# Altium schematic sheet ET-GCLK.SchDoc
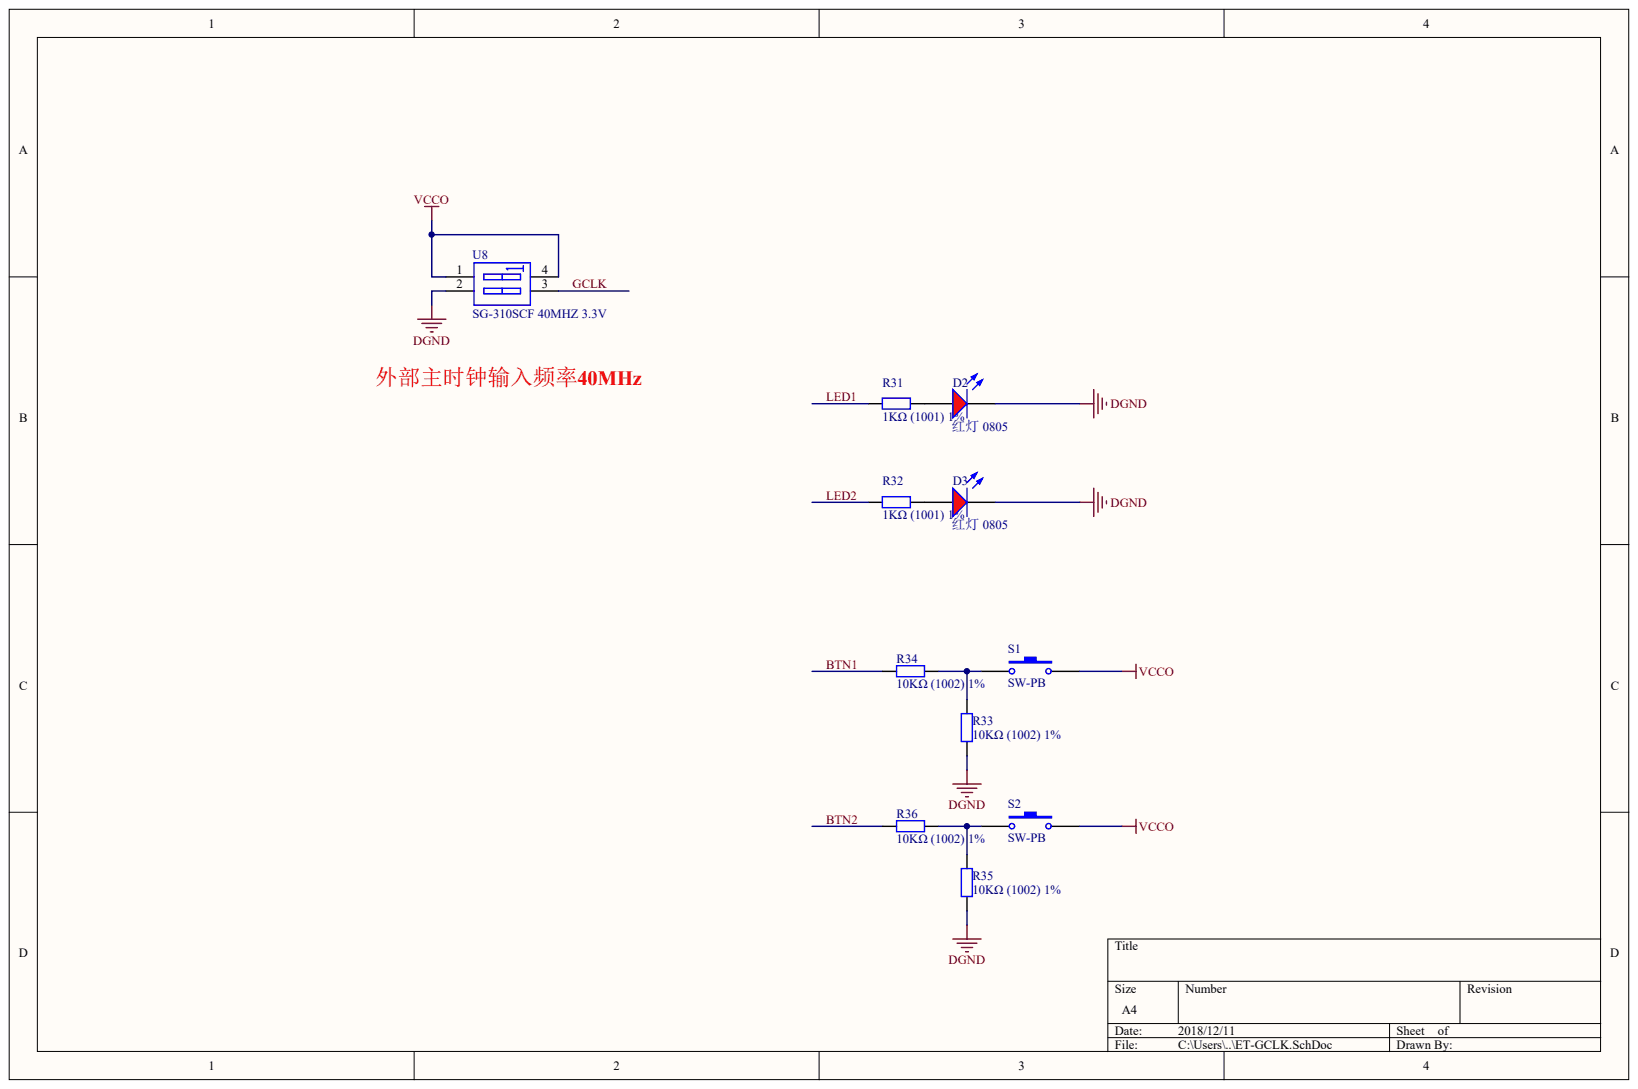
[diagram p0: ET-GCLK.SchDoc]

## Parts
D2  红灯 0805  Package: 0805
D3  红灯 0805  Package: 0805
R31  1KΩ (1001) ±1%
R32  1KΩ (1001) ±1%
R33  10KΩ (1002) ±1%
R34  10KΩ (1002) ±1%
R35  10KΩ (1002) ±1%
R36  10KΩ (1002) ±1%
S1  SW-PB
S2  SW-PB
U8  SG-310SCF 40MHZ 3.3V

## Nets
DGND: D2.K D3.K R33.1 R35.1 U8.2
VCCO: S1.2 S2.2 U8.1 U8.4
BTN1: R34.1
BTN2: R36.1
GCLK: U8.3
LED1: R31.1
LED2: R32.1
NetD2_A: D2.A R31.2
NetD3_A: D3.A R32.2
NetR33_2: R33.2 R34.2 S1.1
NetR35_2: R35.2 R36.2 S2.1

## Library data of the parts
D2 D3 R31-R36 S1 S2 U8 = (no symbol name)
  D2 D3: ComponentLink1Description: 供应商链接; ComponentLink1URL: http://www.szlcsc.com/product/details_2668.html; Supplier: LC; SuppliersPartNumber: C2295
  R31 R32: ComponentLink1Description: 供应商链接; ComponentLink1URL: http://www.szlcsc.com/product/details_18201.html; Package: 0805; Supplier: LC; SuppliersPartNumber: C17513
  R33-R36: ComponentLink1Description: 供应商链接; ComponentLink1URL: http://www.szlcsc.com/product/details_18102.html; Package: 0805; Supplier: LC; SuppliersPartNumber: C17414
  S1 S2: Published: 24-Mar-1999; LatestRevisionDate: 17-Jul-2002; LatestRevisionNote: Re-released for DXP Platform.; PackageReference: SPST-2; PackageDescription: Switch; 2 Leads
  U8: Published: 24-Mar-1999; LatestRevisionDate: 17-Jul-2002; LatestRevisionNote: Re-released for DXP Platform.; PackageReference: DIP-4
  S1 S2 U8: Publisher: Altium Limited
